# Altium schematic sheet DisplayConn.SchDoc
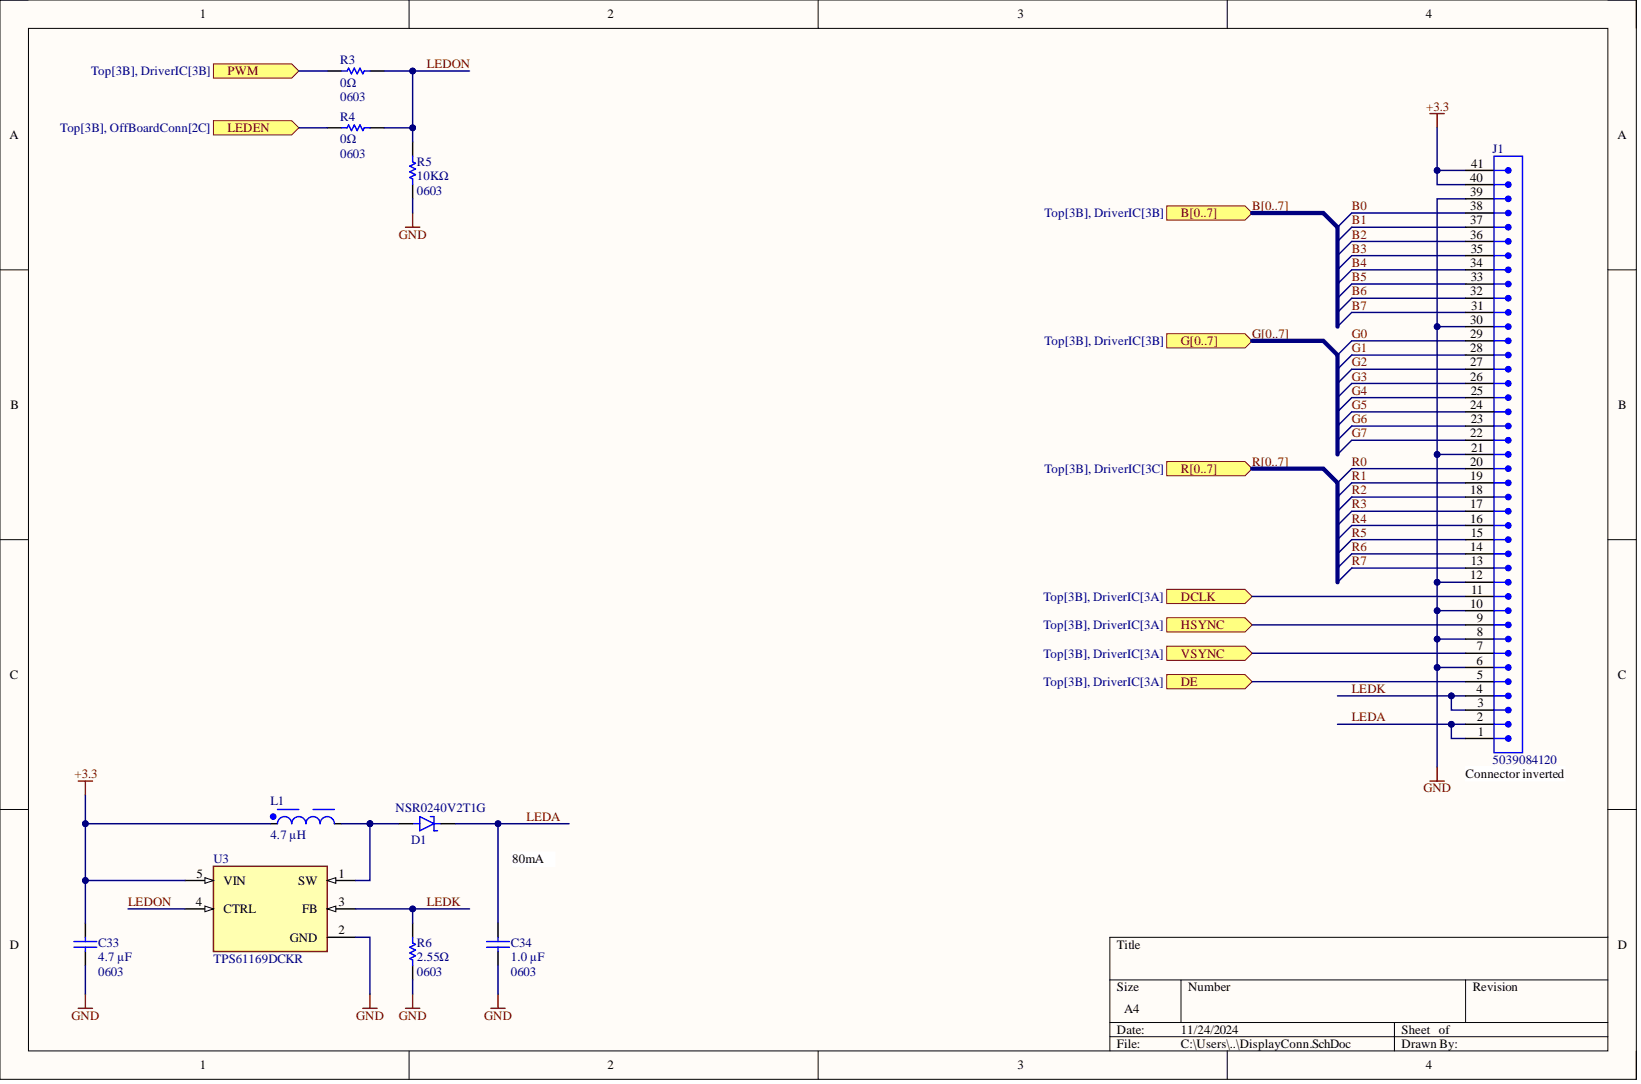
[diagram p0: DisplayConn.SchDoc]

## Parts
C33  value 4.7 µF  [0603]  (hidden comment: GRM188R6YA475KE15D)
C34  value 1.0 µF  [0603]  (hidden comment: GRM188D71H105KE01J)
D1  NSR0240V2T1G  [SOD523]
J1  5039084120  [41POS]
L1  value 4.7 µH  [4.50mm x 4.00mm]  (hidden comment: 744773047)
R3  value 0Ω  [0603]  (hidden comment: RMCF0603ZT0R00)
R4  value 0Ω  [0603]  (hidden comment: RMCF0603ZT0R00)
R5  value 10KΩ  [0603]  (hidden comment: RMCF0603FG10K0)
R6  value 2.55Ω  [0603]  (hidden comment: RMCF0603FT2R55)
U3  TPS61169DCKR  [SOT-353]

## Ports
B0, B1, B2, B3, B4, B5, B6, B7, B[0..7], DCLK, DE, G0, G1, G2, G3, G4, G5, G6, G7, G[0..7], HSYNC, LEDEN, PWM, R0, R1, R2, R3, R4, R5, R6, R7, R[0..7], VSYNC

## Nets
+3.3: C33.2 J1.40 J1.41 L1.1 U3.5(VIN)
GND: C33.1 C34.1 J1.6 J1.8 J1.10 J1.12 J1.21 J1.30 J1.39 R5.2 R6.2 U3.2(GND)
B0: J1.38 ; port B[0..7]  [also labelled B]
B1: J1.37 ; port B[0..7]  [also labelled B]
B2: J1.36 ; port B[0..7]  [also labelled B]
B3: J1.35 ; port B[0..7]  [also labelled B]
B4: J1.34 ; port B[0..7]  [also labelled B]
B5: J1.33 ; port B[0..7]  [also labelled B]
B6: J1.32 ; port B[0..7]  [also labelled B]
B7: J1.31 ; port B[0..7]  [also labelled B]
DCLK: J1.11 ; port DCLK
DE: J1.5 ; port DE
G0: J1.29 ; port G[0..7]  [also labelled G]
G1: J1.28 ; port G[0..7]  [also labelled G]
G2: J1.27 ; port G[0..7]  [also labelled G]
G3: J1.26 ; port G[0..7]  [also labelled G]
G4: J1.25 ; port G[0..7]  [also labelled G]
G5: J1.24 ; port G[0..7]  [also labelled G]
G6: J1.23 ; port G[0..7]  [also labelled G]
G7: J1.22 ; port G[0..7]  [also labelled G]
HSYNC: J1.9 ; port HSYNC
LEDA: C34.2 D1.1 J1.1 J1.2
LEDEN: R4.2 ; port LEDEN
LEDK: J1.3 J1.4 R6.1 U3.3(FB)
LEDON: R3.1 R4.1 R5.1 U3.4(CTRL)
PWM: R3.2 ; port PWM
R0: J1.20 ; port R[0..7]  [also labelled R]
R1: J1.19 ; port R[0..7]  [also labelled R]
R2: J1.18 ; port R[0..7]  [also labelled R]
R3: J1.17 ; port R[0..7]  [also labelled R]
R4: J1.16 ; port R[0..7]  [also labelled R]
R5: J1.15 ; port R[0..7]  [also labelled R]
R6: J1.14 ; port R[0..7]  [also labelled R]
R7: J1.13 ; port R[0..7]  [also labelled R]
VSYNC: J1.7 ; port VSYNC
NetD1_2: D1.2 L1.2 U3.1(SW)

## Library data of the parts
C33 = GRM188R6YA475KE15D: 4.7 µF ±10% 35V Ceramic Capacitor X5R 0603 (1608 Metric); Case: 0603; Component Kind: Standard; Library Name: Murata Electronics.IntLib; Temperature Coefficient: X5R; Tolerance: ±10%; Voltage: 35V
C34 = GRM188D71H105KE01J: 1 µF ±10% 50V Ceramic Capacitor X7T 0603 (1608 Metric); Case: 0603; Component Kind: Standard; Library Name: Murata Electronics.IntLib; Temperature Coefficient: X7T; Tolerance: ±10%; Voltage: 50V
D1 = NSR0240V2T1G: Diode 40 V 250mA Surface Mount SOD-523; DC Reverse: 40V; Component Kind: Standard; Current: 250mA; Library Name: Onsemi.IntLib
J1 = 5039084120: 41 Position FFC Connector Contacts, Bottom 0.020" (0.50mm) Surface Mount, Right Angle; Component Kind: Standard; Library Name: Molex.IntLib
L1 = 744773047: 4.7 µH Unshielded Drum Core, Wirewound Inductor 1.82 A 110mOhm Max Nonstandard; Current: 1.82A; Frequency: 50MHz; Component Kind: Standard; DC Resistance: 110mOhm; Library Name: Wurth Elektronik WE-HCI.IntLib
R3 R4 = RMCF0603ZT0R00: 0 Ohms Jumper Chip Resistor 0603 (1608 Metric) Automotive AEC-Q200 Thick Film; Power: 0; Case: 0603; Component Kind: Standard; Tolerance: 0; Library Name: Stackpole Electronics Inc.IntLib
R5 = RMCF0603FG10K0: 10 kOhms ±1% 0.1W, 1/10W Chip Resistor 0603 (1608 Metric) Automotive AEC-Q200 Thick Film; Case: 0603; Component Kind: Standard; Power: 0.1W; Tolerance: 1%; Library Name: Stackpole Electronics Inc.IntLib
R6 = RMCF0603FT2R55: 2.55 Ohms ±1% 0.1W, 1/10W Chip Resistor 0603 (1608 Metric) Automotive AEC-Q200 Thick Film; Case: 0603; Component Kind: Standard; Power: 0.1W; Tolerance: 1%; Library Name: Stackpole Electronics Inc.IntLib
U3 = TPS61169DCKR: LED Driver IC 1 Output DC DC Regulator Step-Up (Boost) PWM Dimming 1.8A (Switch) SC-70-5; Component Kind: Standard; Library Name: Texas Instruments.IntLib
